# Altium schematic sheet 04_SENSOR-1031.SchDoc
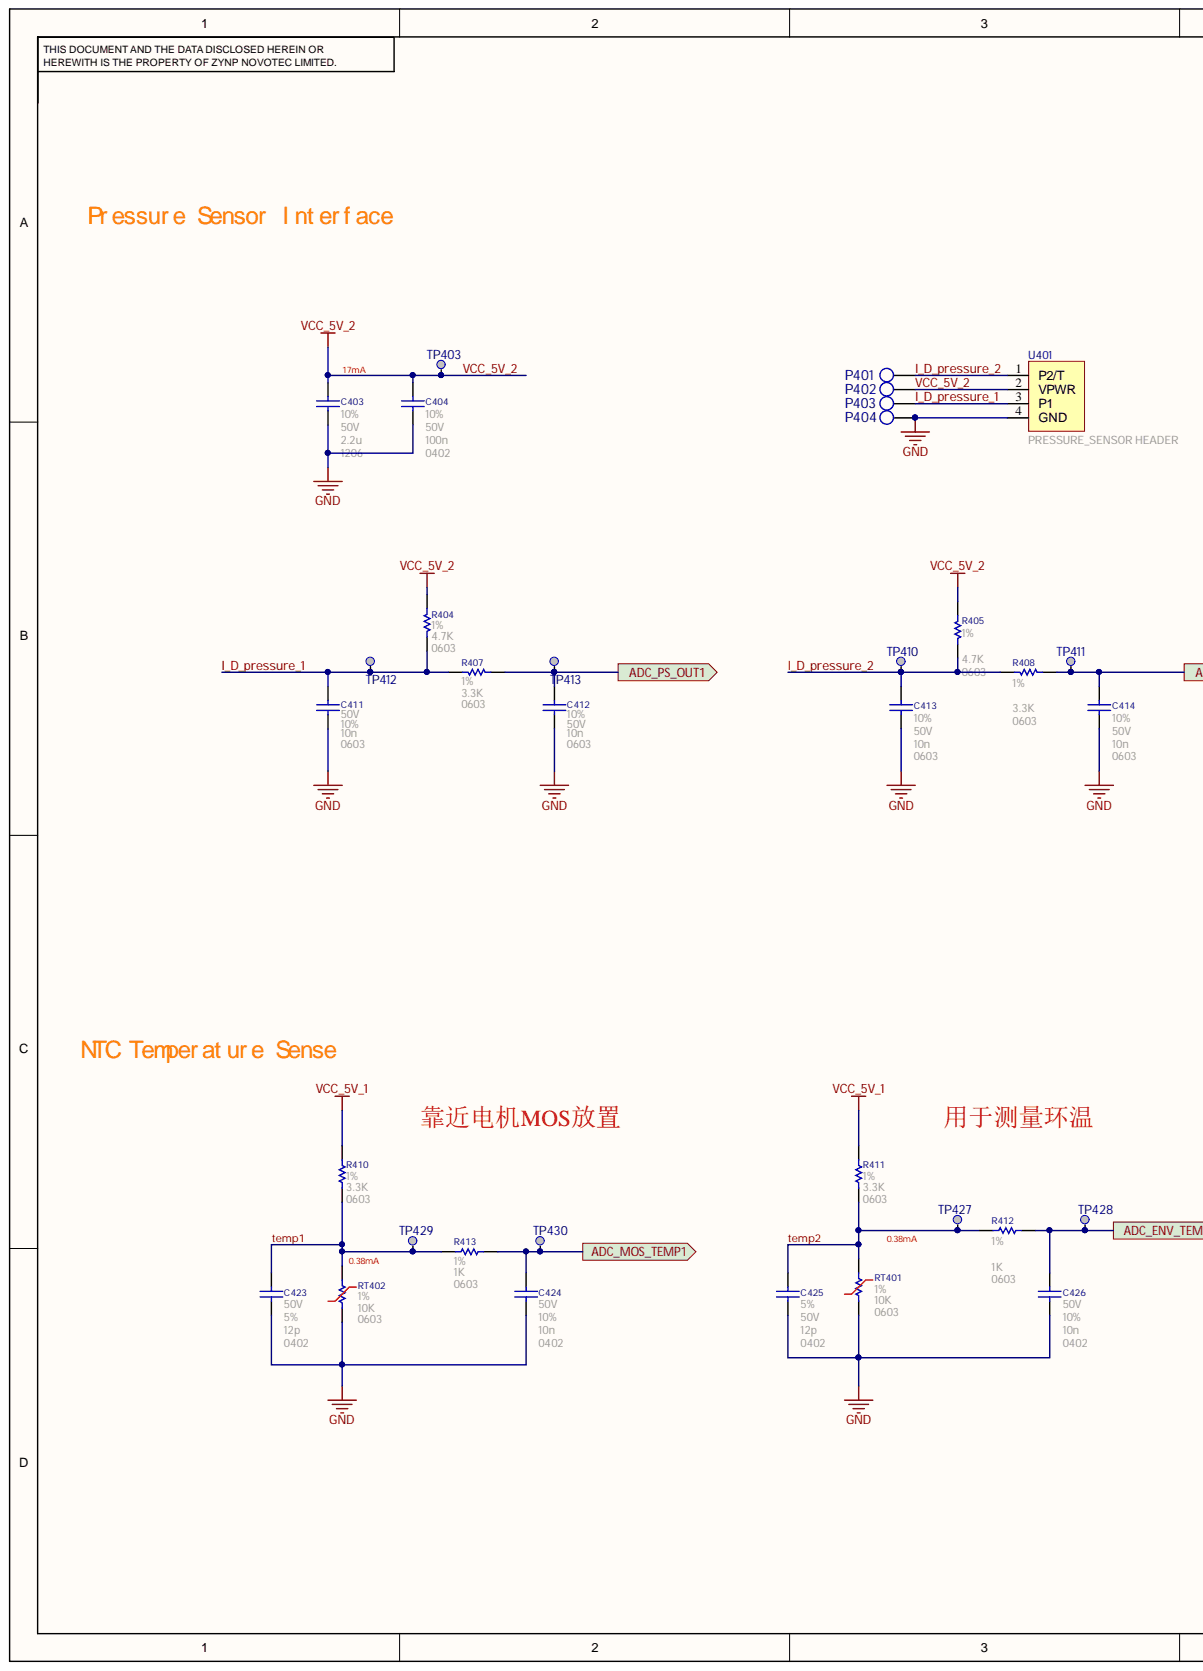
[diagram p0: 04_SENSOR-1031.SchDoc, left]
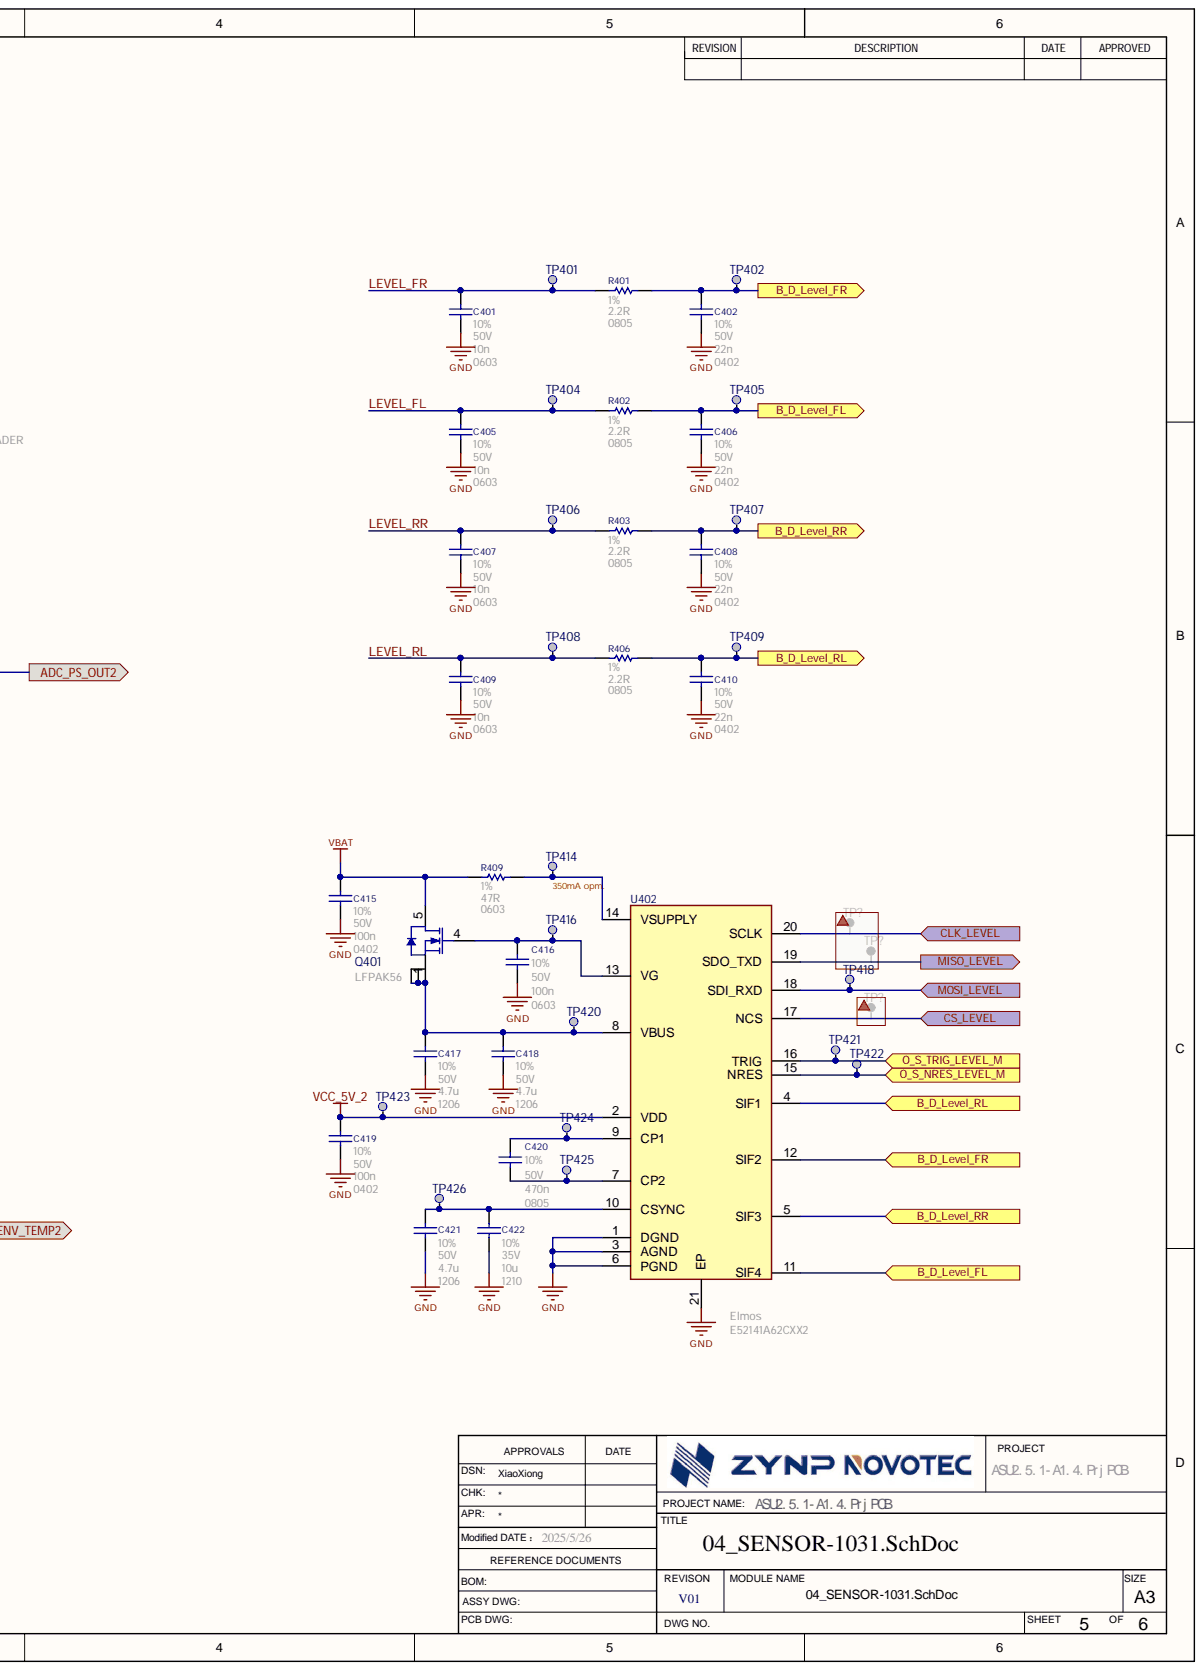
[diagram p1: 04_SENSOR-1031.SchDoc, right]

## Parts
C401  value 10n  Package: 0603  Tolerance: 10%  Voltage: 50V  [C0603]  (hidden comment: CAP)
C402  value 22n  Tolerance: 10%  Voltage: 50V  [C0402]  (hidden comment: CAP)
C403  value 2.2u  Package: 1206  Tolerance: 10%  Voltage: 50V  [C1206]  (hidden comment: CAP)
C404  value 100n  Package: 0402  Tolerance: 10%  Voltage: 50V  [C0402]  (hidden comment: CAP)
C405  value 10n  Package: 0603  Tolerance: 10%  Voltage: 50V  [C0603]  (hidden comment: CAP)
C406  value 22n  Tolerance: 10%  Voltage: 50V  [C0402]  (hidden comment: CAP)
C407  value 10n  Package: 0603  Tolerance: 10%  Voltage: 50V  [C0603]  (hidden comment: CAP)
C408  value 22n  Package: 0402  Tolerance: 10%  Voltage: 50V  [C0402]  (hidden comment: CAP)
C409  value 10n  Package: 0603  Tolerance: 10%  Voltage: 50V  [C0603]  (hidden comment: CAP)
C410  value 22n  Package: 0402  Tolerance: 10%  Voltage: 50V  [C0402]  (hidden comment: CAP)
C411  value 10n  Package: 0603  Tolerance: 10%  Voltage: 50V  [C0603]  (hidden comment: CAP)
C412  value 10n  Package: 0603  Tolerance: 10%  Voltage: 50V  [C0603]  (hidden comment: CAP)
C413  value 10n  Package: 0603  Tolerance: 10%  Voltage: 50V  [C0603]  (hidden comment: CAP)
C414  value 10n  Package: 0603  Tolerance: 10%  Voltage: 50V  [C0603]  (hidden comment: CAP)
C415  value 100n  Tolerance: 10%  Voltage: 50V  [C0402]  (hidden comment: CAP)
C416  value 100n  Tolerance: 10%  Voltage: 50V  [C0603]  (hidden comment: CAP)
C417  value 4.7u  Package: 1206  Tolerance: 10%  Voltage: 50V  [C1206]  (hidden comment: CAP)
C418  value 4.7u  Package: 1206  Tolerance: 10%  Voltage: 50V  [C1206]  (hidden comment: CAP)
C419  value 100n  Package: 0402  Tolerance: 10%  Voltage: 50V  [C0402]  (hidden comment: CAP)
C420  value 470n  Package: 0805  Tolerance: 10%  Voltage: 50V  [C0805]  (hidden comment: CAP)
C421  value 4.7u  Package: 1206  Tolerance: 10%  Voltage: 50V  [C1206]  (hidden comment: CAP)
C422  value 10u  Package: 1210  Tolerance: 10%  Voltage: 35V  [C1210]  (hidden comment: CAP)
C423  value 12p  Package: 0402  Tolerance: 5%  Voltage: 50V  [C0402]  (hidden comment: CAP)
C424  value 10n  Package: 0402  Tolerance: 10%  Voltage: 50V  [C0402]  (hidden comment: CAP)
C425  value 12p  Package: 0402  Tolerance: 5%  Voltage: 50V  [C0402]  (hidden comment: CAP)
C426  value 10n  Package: 0402  Tolerance: 10%  Voltage: 50V  [C0402]  (hidden comment: CAP)
P401  PT-PAD  [PAD-φ3.5]  (value not drawn)
P402  PT-PAD  [PAD-φ3.5]  (value not drawn)
P403  PT-PAD  [PAD-φ3.5]  (value not drawn)
P404  PT-PAD  [PAD-φ3.5]  (value not drawn)
Q401  Package: LFPAK56  [SOT669-1_27-5_0X4_1-H1_2]  (hidden comment: MOS)
R401  value 2.2R  Package: 0805  Tolerance: 1%  [R0805]  (hidden comment: Res)
R402  value 2.2R  Package: 0805  Tolerance: 1%  [R0805]  (hidden comment: Res)
R403  value 2.2R  Package: 0805  Tolerance: 1%  [R0805]  (hidden comment: Res)
R404  value 4.7K  Package: 0603  Tolerance: 1%  [R0603]  (hidden comment: Res)
R405  value 4.7K  Package: 0603  Tolerance: 1%  [R0603]  (hidden comment: Res)
R406  value 2.2R  Package: 0805  Tolerance: 1%  [R0805]  (hidden comment: Res)
R407  value 3.3K  Package: 0603  Tolerance: 1%  [R0603]  (hidden comment: Res)
R408  value 3.3K  Package: 0603  Tolerance: 1%  [R0603]  (hidden comment: Res)
R409  value 47R  Tolerance: 1%  [R0603]  (hidden comment: Res)
R410  value 3.3K  Package: 0603  Tolerance: 1%  [R0603]  (hidden comment: Res)
R411  value 3.3K  Package: 0603  Tolerance: 1%  [R0603]  (hidden comment: Res)
R412  value 1K  Tolerance: 1%  [R0603]  (hidden comment: Res)
R413  value 1K  Package: 0603  Tolerance: 1%  [R0603]  (hidden comment: Res)
RT401  value 10K  Package: 0603  Tolerance: 1%  [R0603-L]  (hidden comment: Res)
RT402  value 10K  Package: 0603  Tolerance: 1%  [R0603-L]  (hidden comment: Res)
TP401  TEST Point  [TP-0.9]  (value not drawn)
TP402  TEST Point  [TP-0.9]  (value not drawn)
TP403  TEST Point  [TP-0.9]  (value not drawn)
TP404  TEST Point  [TP-0.9]  (value not drawn)
TP405  TEST Point  [TP-0.9]  (value not drawn)
TP406  TEST Point  [TP-0.9]  (value not drawn)
TP407  TEST Point  [TP-0.9]  (value not drawn)
TP408  TEST Point  [TP-0.9]  (value not drawn)
TP409  TEST Point  [TP-0.9]  (value not drawn)
TP410  TEST Point  [TP-0.9]  (value not drawn)
TP411  TEST Point  [TP-0.9]  (value not drawn)
TP412  TEST Point  [TP-0.9]  (value not drawn)
TP413  TEST Point  [TP-0.9]  (value not drawn)
TP414  TEST Point  [TP-0.9]  (value not drawn)
TP416  TEST Point  [TP-0.9]  (value not drawn)
TP418  TEST Point  [TP-0.9]  (value not drawn)
TP420  TEST Point  [TP-0.9]  (value not drawn)
TP421  TEST Point  [TP-0.9]  (value not drawn)
TP422  TEST Point  [TP-0.9]  (value not drawn)
TP423  TEST Point  [TP-0.9]  (value not drawn)
TP424  TEST Point  [TP-0.9]  (value not drawn)
TP425  TEST Point  [TP-0.9]  (value not drawn)
TP426  TEST Point  [TP-0.9]  (value not drawn)
TP427  TEST Point  [TP-0.9]  (value not drawn)
TP428  TEST Point  [TP-0.9]  (value not drawn)
TP429  TEST Point  [TP-0.9]  (value not drawn)
TP430  TEST Point  [TP-0.9]  (value not drawn)
U401  PRESSURE_SENSOR HEADER  [pt-sensor]
U402  value E52141A62CXX2  Manufacturer: Elmos  Part_number: E52141A62CXX2  [QFN-24_0.65_5X5X1MM]

## Ports
ADC_ENV_TEMP2, ADC_MOS_TEMP1, ADC_PS_OUT1, ADC_PS_OUT2, B_D_Level_FL, B_D_Level_FR, B_D_Level_RL, B_D_Level_RR, CLK_LEVEL, CS_LEVEL, MISO_LEVEL, MOSI_LEVEL, O_S_NRES_LEVEL_M, O_S_TRIG_LEVEL_M

## Nets
GND: C401.1 C402.1 C403.1 C404.2 C405.1 C406.1 C407.1 C408.1 C409.1 C410.1 C411.1 C412.1 C413.1 C414.1 C415.1 C416.1 C417.1 C418.1 C419.1 C421.1 C422.1 C423.1 C424.1 C425.1 C426.1 P404.1 RT401.1 RT402.1 U401.4(GND) U402.1(DGND) U402.3(AGND) U402.6(PGND) U402.21(EP)
VBAT: C415.2 Q401.5 R409.1
VCC_5V_1: R410.2 R411.2
ADC_ENV_TEMP2: C426.2 R412.2 TP428.1 ; port ADC_ENV_TEMP2
ADC_MOS_TEMP1: C424.2 R413.2 TP430.1 ; port ADC_MOS_TEMP1
ADC_PS_OUT1: C412.2 R407.1 TP413.1 ; port ADC_PS_OUT1
ADC_PS_OUT2: C414.2 R408.1 TP411.1 ; port ADC_PS_OUT2
B_D_Level_FL: C406.2 R402.2 TP405.1 U402.11(SIF4) ; port B_D_Level_FL
B_D_Level_FR: C402.2 R401.2 TP402.1 U402.12(SIF2) ; port B_D_Level_FR
B_D_Level_RL: C410.2 R406.2 TP409.1 U402.4(SIF1) ; port B_D_Level_RL
B_D_Level_RR: C408.2 R403.2 TP407.1 U402.5(SIF3) ; port B_D_Level_RR
CLK_LEVEL: U402.20(SCLK) ; port CLK_LEVEL
CS_LEVEL: U402.17(NCS) ; port CS_LEVEL
I_D_pressure_1: C411.2 P403.1 R404.1 R407.2 TP412.1 U401.3(P1)
I_D_pressure_2: C413.2 P401.1 R405.1 R408.2 TP410.1 U401.1(P2/T)
LEVEL_FL: C405.2 R402.1 TP404.1
LEVEL_FR: C401.2 R401.1 TP401.1
LEVEL_RL: C409.2 R406.1 TP408.1
LEVEL_RR: C407.2 R403.1 TP406.1
MISO_LEVEL: U402.19(SDO_TXD) ; port MISO_LEVEL
MOSI_LEVEL: TP418.1 U402.18(SDI_RXD) ; port MOSI_LEVEL
O_S_NRES_LEVEL_M: TP422.1 U402.15(NRES) ; port O_S_NRES_LEVEL_M
O_S_TRIG_LEVEL_M: TP421.1 U402.16(TRIG) ; port O_S_TRIG_LEVEL_M
temp1: C423.2 R410.1 R413.1 RT402.2 TP429.1
temp2: C425.2 R411.1 R412.1 RT401.2 TP427.1
VCC_5V_2: C403.2 C404.1 C419.2 P402.1 R404.2 R405.2 TP403.1 TP423.1 U401.2(VPWR) U402.2(VDD)
NetC416_2: C416.2 Q401.4 TP416.1 U402.13(VG)
NetC417_2: C417.2 C418.2 Q401.1 Q401.2 Q401.3 TP420.1 U402.8(VBUS)
NetC420_1: C420.1 TP425.1 U402.7(CP2)
NetC420_2: C420.2 TP424.1 U402.9(CP1)
NetC421_2: C421.2 C422.2 TP426.1 U402.10(CSYNC)
NetR409_2: R409.2 TP414.1 U402.14(VSUPPLY)

## Library data of the parts
C401 C405 C407 C409 C411-C414 = C_10n_50V_10%_GCM188R71H103KA37D: 112000172; Manufacturer: Murata; Location: Japan; Regulation: GCM188R71H103KA37D、Murata、10n、10%、50V、X7R、-55℃~125℃、0603; SAP_number: 10056381; Specification: RoHS AEC-Q200; Temperature_char: X7R; Type: 陶瓷电容; Component Kind: Standard; Library Name: RLC.SchLib; Part_number: GCM188R71H103KA37D; TC_number: 112000172; Temperature: -55℃~125℃
C402 C406 C408 C410 = C_22n_50V_10%_GCM155R71H223KA55D: 112000160; Manufacturer: Murata; Location: Japan; Regulation: GCM155R71H223KA55D、Murata、22n、10%、50V、X7R、-55℃~125℃、0402; SAP_number: 10056377; Specification: RoHS AEC-Q200; Temperature_char: X7R; Type: 陶瓷电容; Component Kind: Standard; Library Name: RLC.SchLib; Part_number: GCM155R71H223KA55D; TC_number: 112000160; Temperature: -55℃~125℃
  C402 C406: Package: 0402
C403 = C_2.2u_50V_10%_GCM31CR71H225KA55L: 112000109; Manufacturer: Murata; Location: Japan; Regulation: GCM31CR71H225KA55L、Murata、2.2u、10%、50V、X7R、-55℃~125℃、1206; SAP_number: 10056844; Specification: RoHS AEC-Q200; Temperature_char: X7R; Type: 陶瓷电容; Component Kind: Standard; Library Name: RLC.SchLib; Part_number: GCM31CR71H225KA55L; TC_number: 112000109; Temperature: -55℃~125℃
C404 C415 C419 = C_100n_50V_10%_GCM155R71H104KE02D: 112000115; Manufacturer: Murata; Location: Japan; Regulation: GCM155R71H104KE02D、Murata、100n、10%、50V、X7R、-55℃~125℃、0402; SAP_number: 10052237; Specification: RoHS AEC-Q200; Temperature_char: X7R; Type: 陶瓷电容; Component Kind: Standard; Library Name: RLC.SchLib; Part_number: GCM155R71H104KE02D; TC_number: 112000115; Temperature: -55℃~125℃
  C415: Package: 0402
C416 = C_100n_50V_10%_GCM188R71H104KA57D: 112000101; Manufacturer: Murata; Location: Japan; Regulation: GCM188R71H104KA57D、Murata、100n、10%、50V、X7R、-55℃~125℃、0603; SAP_number: 10055330; Specification: RoHS AEC-Q200; Temperature_char: X7R; Type: 陶瓷电容; Component Kind: Standard; Library Name: RLC.SchLib; Package: 0603; Part_number: GCM188R71H104KA57D; TC_number: 112000101; Temperature: -55℃~125℃
C417 C418 C421 = C_4.7u_50V_10%_GCM31CC71H475KA03L: 112000110; Manufacturer: Murata; Location: Japan; Regulation: GCM31CC71H475KA03L、Murata、4.7u、10%、50V、X7R、-55℃~125℃、1206; SAP_number: 10055346; Specification: RoHS AEC-Q200; Temperature_char: X7R; Type: 陶瓷电容; Component Kind: Standard; Library Name: RLC.SchLib; Part_number: GCM31CC71H475KA03L; TC_number: 112000110; Temperature: -55℃~125℃
C420 = C_470n_50V_10%_GCM21BR71H474KA55L: 112000116; Manufacturer: Murata; Location: Japan; Regulation: GCM21BR71H474KA55L、Murata、470n、10%、50V、X7R、-55℃~125℃ 、0805; SAP_number: 10056390; Specification: RoHS AEC-Q200; Temperature_char: X7R; Type: 陶瓷电容; Component Kind: Standard; Library Name: RLC.SchLib; Part_number: GCM21BR71H474KA55L; TC_number: 112000116; Temperature: -55℃~125℃
C422 = C_10u_35V_10%_GCM32EC7YA106KA03L: 112000229; Manufacturer: Murata; Location: Japan; Regulation: GCM32EC7YA106KA03L、Murata、10u、10%、35V、X7S、-55℃~125℃、1210; SAP_number: 10056483; Specification: RoHS AEC-Q200; Temperature_char: X7S; Type: 陶瓷电容; Component Kind: Standard; Library Name: RLC.SchLib; Part_number: GCM32EC7YA106KA03L; TC_number: 112000229; Temperature: -55℃~125℃
C423 C425 = C_12p_50V_5%_GCM1555C1H120JA16D: Manufacturer: Murata; Location: Japan; Regulation: GCM1555C1H120JA16D、Murata、12p、±5%、50V、C0G、-55℃~125℃、0402; Specification: RoHS AEC-Q200; Temperature_char: C0G; Type: 陶瓷电容; Component Kind: Standard; Library Name: RLC.SchLib; Part_number: GCM1555C1H120JA16D; Temperature: -55℃~125℃
C424 C426 = C_10n_50V_10%_GCM155R71H103KA55D: 112000097; Manufacturer: Murata; Location: Japan; Regulation: GCM155R71H103KA55D、Murata、10n、10%、50V、X7R、-55℃~125℃、0402; SAP_number: 10052234; Specification: RoHS AEC-Q200; Temperature_char: X7R; Type: 陶瓷电容; Component Kind: Standard; Library Name: RLC.SchLib; Part_number: GCM155R71H103KA55D; TC_number: 112000097; Temperature: -55℃~125℃
P401-P404 = PT-PAD: fi3.0*H2.0; Manufacturer: 金启顺; Part_number: φ3.0*H2.0; Regulation: φ3.0*H2.0；基材：铜； 镀层：金；镀金厚度3迈; Component Kind: Standard; Library Name: Header.SchLib; Package: PAD-φ3.5; Type: 镀金垫片
Q401 = Q_BUK7Y12-40E: 118000005; Manufacturer: Nexperia; Channel_type: N-channel; Id or Ic: 52A; RDS(on): 12mΩ@10V; Regulation: BUK7Y12-40E、Nexperia、NMOSFET、52A、±20V、12mR、40V、-55℃~175℃、SOT-669（SC-100）; SAP_number: 10056577; Specification: RoHS AEC-Q101; Type: NMOSFET; Vds or Vce: 40V; Vgs or Vbe: ±20V; Component Kind: Standard; Library Name: MOSFET.SchLib; Part_number: BUK7Y12-40E; TC_number: 118000005; Temperature: -55℃～175℃
R401-R403 R406 = R_2.2R_1/8W_1%_AC0805FR-072R2L: AC0805FR-072R2L、YAGEO、2R2、1%、0805; Manufacturer: YAGEO; Location: taiwan; Power: 1/8W; Specification: RoHS AEC-Q200; Component Kind: Standard; Library Name: RLC.SchLib; Part_number: AC0805FR-072R2L; Regulation: AC0805FR-072R2L、YAGEO、2R2、1%、0805; Temperature: -55℃～155℃; Type: 厚膜电阻
R404 R405 = R_4.7K_1/10W_1%_AC0603FR-074K7L: AC0603FR-074K7L、YAGEO、4.7K、1%、0603; Manufacturer: YAGEO; Location: taiwan; Power: 1/10W; Specification: RoHS AEC-Q200; Component Kind: Standard; Library Name: RLC.SchLib; Part_number: AC0603FR-074K7L; Regulation: AC0603FR-074K7L、YAGEO、4.7K、1%、0603; Temperature: -55℃～155℃; Type: 厚膜电阻
R407 R408 R410 R411 = R_3.3K_1/10W_1%_AC0603FR-073K3L: AC0603FR-073K3L、YAGEO、3.3K、1%、0603; Manufacturer: YAGEO; Location: taiwan; Power: 1/10W; Specification: RoHS AEC-Q200; Component Kind: Standard; Library Name: RLC.SchLib; Part_number: AC0603FR-073K3L; Regulation: AC0603FR-073K3L、YAGEO、3.3K、1%、0603; Temperature: -55℃～155℃; Type: 厚膜电阻
R409 = R_47R_1/10W_1%_AC0603FR-0747RL: AC0603FR-0747RL、YAGEO、47R、1%、0603; Manufacturer: YAGEO; Location: taiwan; Power: 1/10W; Specification: RoHS AEC-Q200; Value: 47R; Component Kind: Standard; Library Name: RLC.SchLib; Package: 0603; Part_number: AC0603FR-0747RL; Regulation: AC0603FR-0747RL、YAGEO、47R、1%、0603; Temperature: -55℃～155℃; Type: 厚膜电阻
R412 R413 = R_1K_1/10W_1%_AC0603FR-071KL: Manufacturer: YAGEO; Location: taiwan; Power: 1/10W; Regulation: AC0603FR-071KL、YAGEO、1K、1%、0603; Specification: RoHS AEC-Q200; Component Kind: Standard; Library Name: RLC.SchLib; Part_number: AC0603FR-071KL; Temperature: -55℃～155℃; Type: 厚膜电阻
  R412: Package: 0603
RT401 RT402 = R_10K_1/10W_1%_ NTCG163JF103FT1S: 111000056; Manufacturer: TDK; Location: Japan; Power: 1/10W; Regulation: NTCG163JF103FT1S、TDK、10k、1%、1/10W、-40℃~150℃、0603; SAP_number: 10055375; Specification: RoHS AEC-Q200; Component Kind: Standard; Library Name: RLC.SchLib; Part_number: NTCG163JF103FT1S; TC_number: 111000056; Temperature: -55℃～155℃; Type: 热敏电阻
TP401-TP414 TP416 TP418 TP420-TP430 = TEST_PIN_0.9: TP; Component Kind: Standard; Library Name: Header.SchLib; Package: TP-0.9; Type: test pin; Value: TEST
U401 = PRESSURE_SENSOR HEADER: pressure sensor; Component Kind: Standard; Library Name: 二合一转接板0428.SCHLIB
U402 = U_E52141A62CXX2: 117000090; Regulation: E52141A62CXX2、Elmos、PSI5、-40℃~125℃、QFN20L5; Component Kind: Standard; Library Name: IC.SchLib; Package: QFN20L5; Temperature: -40℃~125℃; TP_number: 117000090; Type: PSI收发器
